# Altium schematic sheet 06_POWER_2.SchDoc(06_POWER_2)
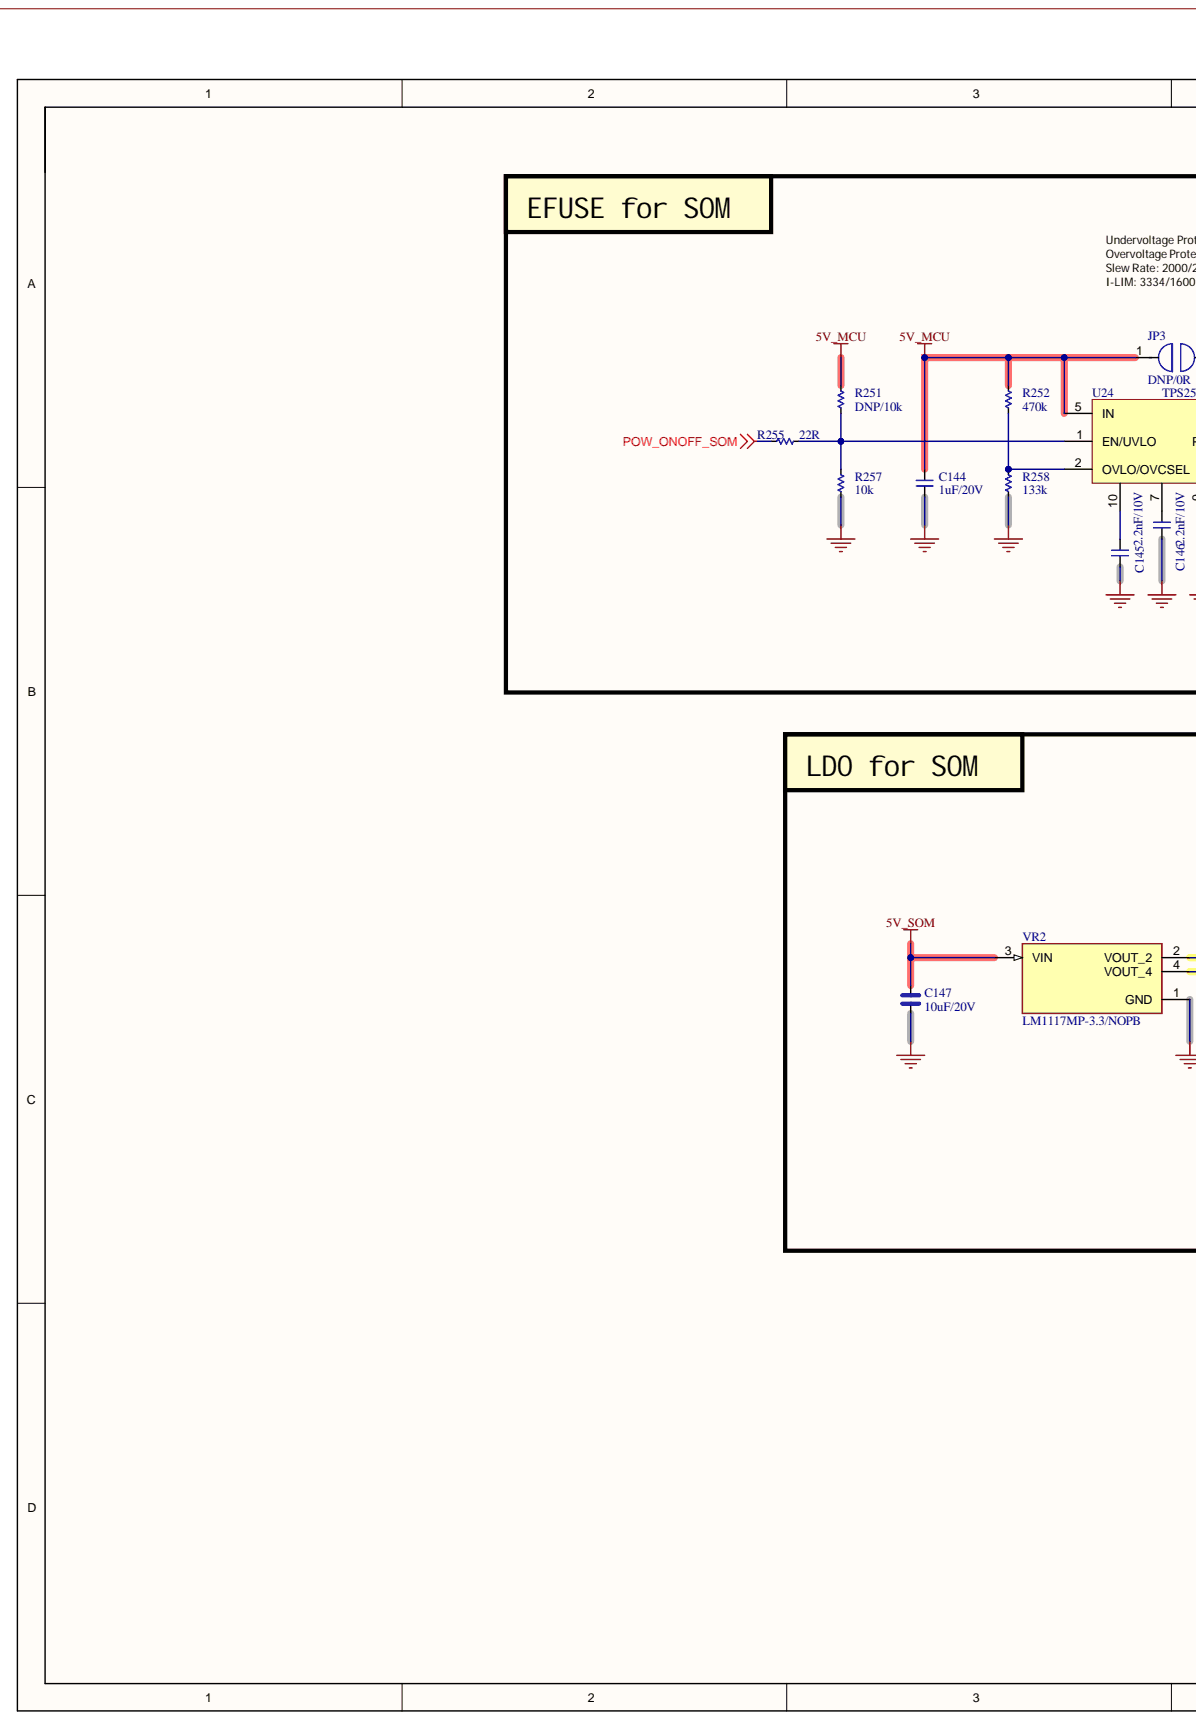
[diagram p0: 06_POWER_2.SchDoc, left]
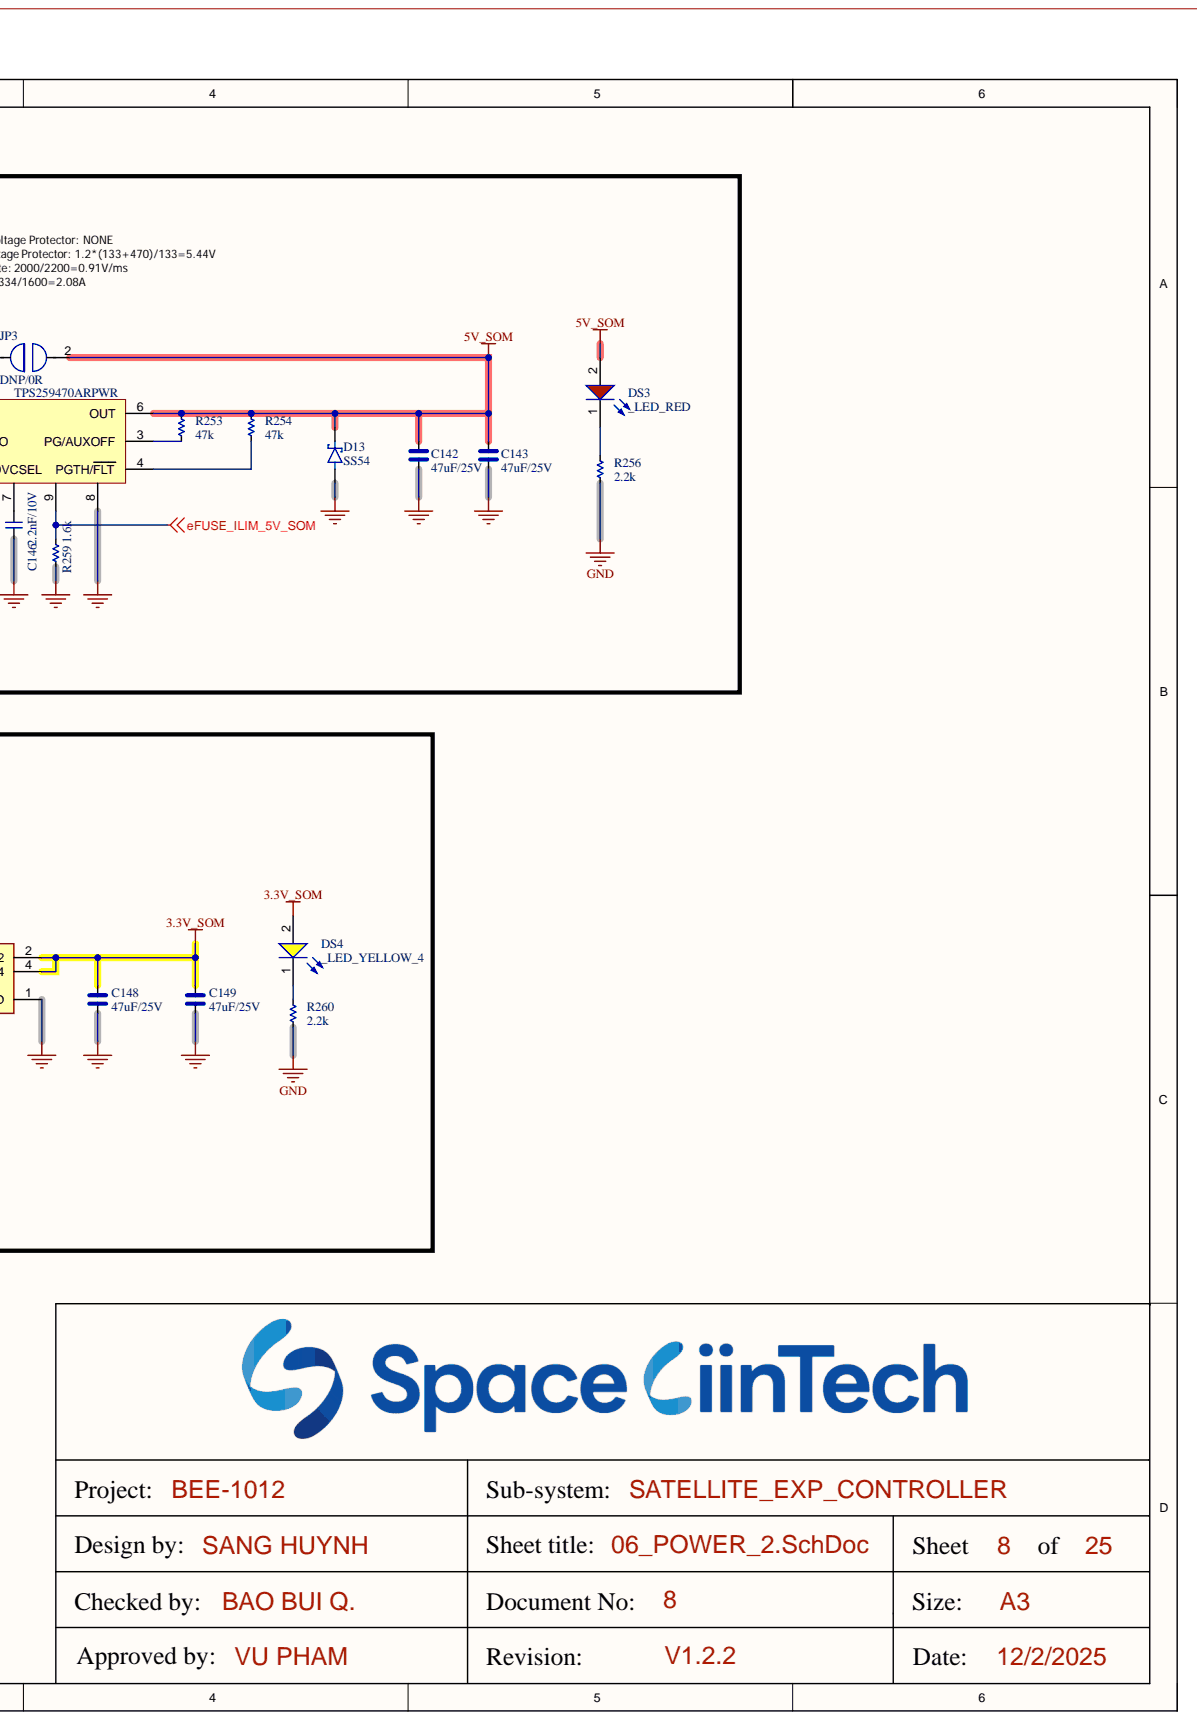
[diagram p1: 06_POWER_2.SchDoc, right]

## Parts
C142  47uF/25V  [CAP_C_3225X80]
C143  47uF/25V  [CAP_C_3225X80]
C144  1uF/20V  [CAP_C_1005X60]
C145  2.2nF/10V  [CAP_C_1005X60]
C146  2.2nF/10V  [CAP_C_1005X60]
C147  10uF/20V  [CAP_C_2012X110]
C148  47uF/25V  [CAP_C_3225X80]
C149  47uF/25V  [CAP_C_3225X80]
D13  SS54  [DO-214AC_SMA]
DS3  _LED_RED  [LED_0402]
DS4  _LED_YELLOW_4  [LED_0402]
JP3  DNP/0R  [RES_C_1608X60]
R251  DNP/10k  [RES_C_1005X40]
R252  470k  [RES_C_1005X40]
R253  47k  [RES_C_1005X40]
R254  47k  [RES_C_1005X40]
R255  22R  [RES_C_1005X40]
R256  2.2k  [RES_C_1005X40]
R257  10k  [RES_C_1005X40]
R258  133k  [RES_C_1005X40]
R259  1.6k  [RES_C_1005X40]
R260  2.2k  [RES_C_1005X40]
U24  TPS259470ARPWR  [VQFN-HR-10]
VR2  LM1117MP-3.3/NOPB  [VREG_LM1117MP-3.3/NOPB]

## Nets
3.3V_SOM: C148.2 C149.2 DS4.2 VR2.2(VOUT_2) VR2.4(VOUT_4)
5V_MCU: C144.2 JP3.1 R251.2 R252.2 U24.5(IN)
5V_SOM: C142.2 C143.2 C147.1 D13.1 DS3.2 JP3.2 R253.2 R254.2 U24.6(OUT) VR2.3(VIN)
eFUSE_ILIM_5V_SOM: R259.2 U24.9
GND: C142.1 C143.1 C144.1 C145.1 C146.1 C147.2 C148.1 C149.1 D13.2 R256.1 R257.2 R258.1 R259.1 R260.1 U24.8 VR2.1(GND)
POW_ONOFF_SOM: R255.2
NetC145_2: C145.2 U24.10
NetC146_2: C146.2 U24.7
NetDS3_1: DS3.1 R256.2
NetDS4_1: DS4.1 R260.2
NetR251_1: R251.1 R255.1 R257.1 U24.1(EN/UVLO)
NetR252_1: R252.1 R258.2 U24.2(OVLO/OVCSEL)
NetR253_1: R253.1 U24.3(PG/AUXOFF)
NetR254_1: R254.1 U24.4(PGTH/FLT)

## Library data of the parts
C142 C143 C147-C149 = _CAP_C_High_2: CAP CHIP High Value; Component Kind: Standard; Library Name: LABLIB.SchLib
C144-C146 = _CAP_C_2: CAP CHIP; Component Kind: Standard; Library Name: LABLIB.SchLib
D13 = DIODE SCHOTTKY: Component Kind: Standard; Description: DIODE SCHOTTKY; Library Name: LABLIB.SchLib
JP3 = JUMPER: Component Kind: Standard; Library Name: EXP_V1.SchLib
R251-R260 = _RES_B_2: RES; Component Kind: Standard; Library Name: LABLIB.SchLib
VR2 = LM1117MP-3.3/NOPB: 800-mA 15-V linear voltage regulator; MF: Texas Instruments; Check_prices: https://www.snapeda.com/parts/LM1117MP-3.3/NOPB/Texas+Instruments/view-part/?ref=eda; Component Kind: Standard; Library Name: new_component.SchLib; MP: LM1117MP-3.3/NOPB; Package: SOT-223-4 Texas Instruments; SnapEDA_Link: https://www.snapeda.com/parts/LM1117MP-3.3/NOPB/Texas+Instruments/view-part/?ref=snap
DS3 = _LED_RED: Component Kind: Standard; Library Name: LABLIB.SchLib
DS4 = _LED_YELLOW_4: Component Kind: Standard; Library Name: LABLIB.SchLib
U24 = TPS259470ARPWR: Component Kind: Standard; Library Name: EXP_HW_V3.SchLib
